# Altium schematic sheet Sheet6.SchDoc(Sheet6)
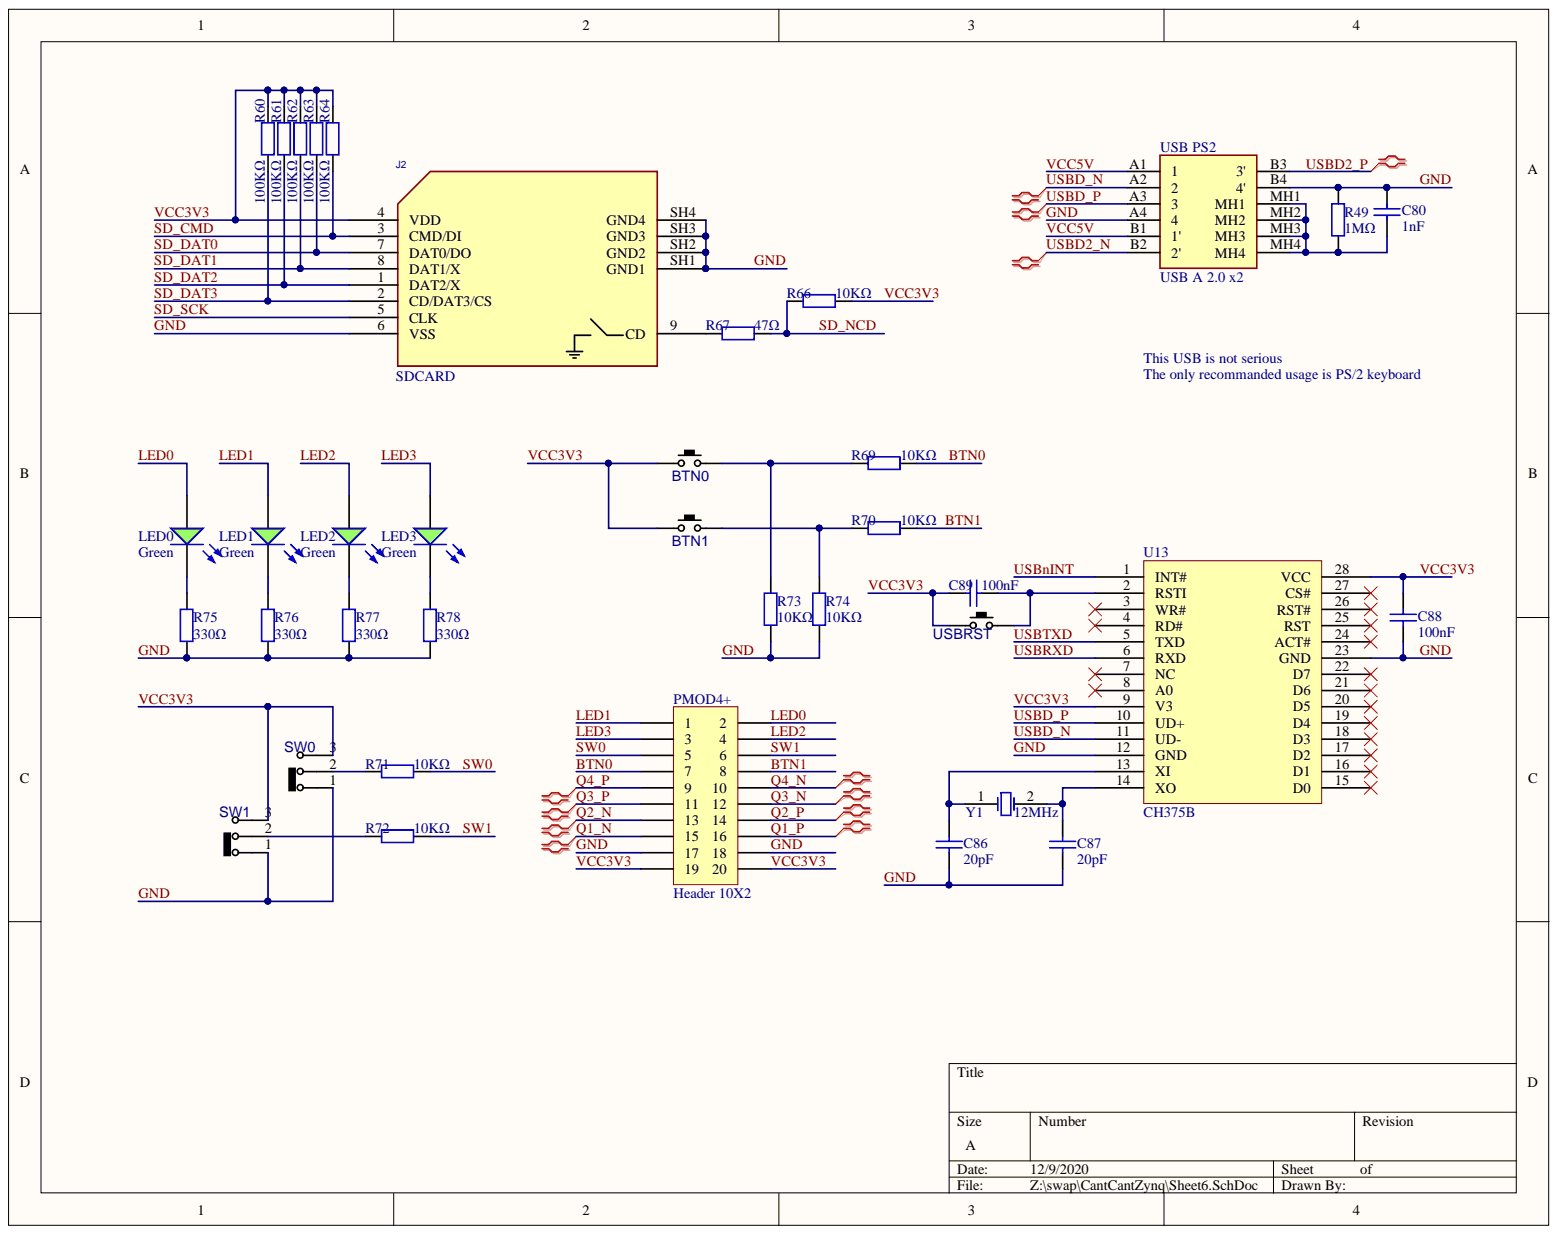
[diagram p0: Sheet6.SchDoc]

## Parts
BTN0  PUSHBUTTON  [SWPBS_SPST_6X3X5]  (value not drawn)
BTN1  PUSHBUTTON  [SWPBS_SPST_6X3X5]  (value not drawn)
C80  1nF  [0805_C]
C86  20pF  [0805_C]
C87  20pF  [0805_C]
C88  100nF  [1206_C]
C89  100nF  [1206_C]
J2  SDCARD  [SDMICRO_AT]
LED0  Green  [0805_LED_S1]
LED1  Green  [0805_LED_S1]
LED2  Green  [0805_LED_S1]
LED3  Green  [0805_LED_S1]
PMOD4+  Header 10X2  [HDR2X10]
R49  1MΩ  [0805_R]
R60  100KΩ  [1206_R]
R61  100KΩ  [1206_R]
R62  100KΩ  [1206_R]
R63  100KΩ  [1206_R]
R64  100KΩ  [1206_R]
R66  10KΩ  [1206_R]
R67  47Ω  [0805_R]
R69  10KΩ  [1206_R]
R70  10KΩ  [1206_R]
R71  10KΩ  [1206_R]
R72  10KΩ  [1206_R]
R73  10KΩ  [1206_R]
R74  10KΩ  [1206_R]
R75  330Ω  [0805_R]
R76  330Ω  [0805_R]
R77  330Ω  [0805_R]
R78  330Ω  [0805_R]
SW0  SlideSwitch  [SWSLIDT_SPDT_S_8X4]  (value not drawn)
SW1  SlideSwitch  [SWSLIDT_SPDT_S_8X4]  (value not drawn)
U13  CH375B  [SOIC-28_300mil]
USB_PS2  USB A 2.0 x2  [0672983090]
USBRST  PUSHBUTTON  [SWPBS_SPST_6X3X5]  (value not drawn)
Y1  12MHz  [SMD-5032_2P]

## Nets
BTN0: PMOD4+.7 R69.2
BTN1: PMOD4+.8 R70.2
GND: C80.1 C86.1 C87.1 C88.1 J2.6(VSS) J2.SH1(GND1) J2.SH2(GND2) J2.SH3(GND3) J2.SH4(GND4) PMOD4+.17 PMOD4+.18 R49.1 R73.1 R74.1 R75.1 R76.1 R77.1 R78.1 SW0.1 SW1.1 U13.12(GND) U13.23(GND) USB_PS2.A4 USB_PS2.B4(4')
LED0: LED0.A PMOD4+.2
LED1: LED1.A PMOD4+.1
LED2: LED2.A PMOD4+.4
LED3: LED3.A PMOD4+.3
Q1_N: PMOD4+.15
Q1_P: PMOD4+.16
Q2_N: PMOD4+.13
Q2_P: PMOD4+.14
Q3_N: PMOD4+.12
Q3_P: PMOD4+.11
Q4_N: PMOD4+.10
Q4_P: PMOD4+.9
SD_CMD: J2.3(CMD/DI) R64.1
SD_DAT0: J2.7(DAT0/DO) R63.1
SD_DAT1: J2.8(DAT1/X) R62.1
SD_DAT2: J2.1(DAT2/X) R61.1
SD_DAT3: J2.2(CD/DAT3/CS) R60.1
SD_NCD: R66.1 R67.2
SD_SCK: J2.5(CLK)
SW0: PMOD4+.5 R71.2
SW1: PMOD4+.6 R72.2
USBD2_N: USB_PS2.B2(2')
USBD2_P: USB_PS2.B3(3')
USBD_N: U13.11(UD-) USB_PS2.A2
USBD_P: U13.10(UD+) USB_PS2.A3
USBnINT: U13.1(INT#)
USBRXD: U13.6(RXD)
USBTXD: U13.5(TXD)
VCC3V3: BTN0.1 BTN1.1 C88.2 C89.2 J2.4(VDD) PMOD4+.19 PMOD4+.20 R60.2 R61.2 R62.2 R63.2 R64.2 R66.2 SW0.3 SW1.3 U13.9(V3) U13.28(VCC) USBRST.1
VCC5V: USB_PS2.A1 USB_PS2.B1(1')
NetBTN0_2: BTN0.2 R69.1 R73.2
NetBTN1_2: BTN1.2 R70.1 R74.2
NetC80_2: C80.2 R49.2 USB_PS2.MH1 USB_PS2.MH2 USB_PS2.MH3 USB_PS2.MH4
NetC86_2: C86.2 U13.13(XI) Y1.1
NetC87_2: C87.2 U13.14(XO) Y1.2
NetC89_1: C89.1 U13.2(RSTI) USBRST.2
NetJ2_9: J2.9(CD) R67.1
NetLED0_K: LED0.K R75.2
NetLED1_K: LED1.K R76.2
NetLED2_K: LED2.K R77.2
NetLED3_K: LED3.K R78.2
NetR71_1: R71.1 SW0.2
NetR72_1: R72.1 SW1.2

Not connected: U13 3(WR#) 4(RD#) 7(NC) 8(A0) 15(D0) 16(D1) 17(D2) 18(D3) 19(D4) 20(D5) 21(D6) 22(D7) 24(ACT#) 25(RST) 26(RST#) 27(CS#)

## Library data of the parts
BTN0 BTN1 USBRST = SWPBS_SPST_6X3X4: SWITCH, PUSH BUTTON, TACTILE, SMD, SPST, 6X3.5X4.3mm; MANF_P#: EVQ-PNF04M; MANF: Panasonic; DESC: DESCRIPTION; VALUE: PUSHBUTTON; PRICE: PRICE; PARAM: PARAM; Component Kind: Standard; ASSEMBLY: ASSEMBLY; Library Name: Switch Push Botton.SchLib
C80 = 0805 1nF (102) 10% 50V: 贴片电容; SuppliersPartNumber: C51210; Supplier: LC; Package: 0805; Library Name: Cap.SchLib; ComponentLink1URL: http://www.szlcsc.com/product/details_52223.html; ComponentLink1Description: 供应商链接; Component Kind: Standard
C86 C87 = 0805 20pF (200) 5% 50V: 贴片电容; SuppliersPartNumber: C1798; Supplier: LC; Package: 0805; Library Name: Cap.SchLib; ComponentLink1URL: http://www.szlcsc.com/product/details_2150.html; ComponentLink1Description: 供应商链接; Component Kind: Standard
C88 C89 = 1206 100nF (104) 10% 50V: 贴片电容; SuppliersPartNumber: C24497; Supplier: LC; Package: 1206; Library Name: Cap.SchLib; ComponentLink1URL: http://www.szlcsc.com/product/details_25237.html; ComponentLink1Description: 供应商链接; Component Kind: Standard
J2 = SOCKET_SDMICRO_AT: SD MICRO exist in Iran, Attend 112J-TDAR-R01; VALUE: SDCARD; MANF_P#: 112J-TDAR-R01; MANF: Attend; Library Name: Connector Micro SD.SchLib; Component Kind: Standard
LED0-LED3 = 0805 翠绿: 发光二极管; SuppliersPartNumber: C2297; Supplier: LC; Package: 0805; Library Name: LED.SchLib; ComponentLink1URL: http://www.szlcsc.com/product/details_2670.html; ComponentLink1Description: 供应商链接; Component Kind: Standard
PMOD4+ = Header 10X2: Header, 10-Pin, Dual row; Signal Integrity: Connector; Library Name: Miscellaneous Connectors.IntLib; LatestRevisionNote: Re-released for DXP Platform.; LatestRevisionDate: 17-Jul-2002; Component Kind: Standard; Publisher: Altium Limited
R49 = 0805 1MΩ (1004) 1%: 贴片电阻; SuppliersPartNumber: C17514; Supplier: LC; Package: 0805; Library Name: RES.SchLib; ComponentLink1URL: http://www.szlcsc.com/product/details_18202.html; ComponentLink1Description: 供应商链接; Component Kind: Standard
R60-R64 = 1206 100KΩ (1003) 1%: 贴片电阻; SuppliersPartNumber: C17900; Supplier: LC; Package: 1206; Library Name: RES.SchLib; ComponentLink1URL: http://www.szlcsc.com/product/details_18588.html; ComponentLink1Description: 供应商链接; Component Kind: Standard
R66 R69-R74 = 1206 10KΩ (1002) 1%: 贴片电阻; SuppliersPartNumber: C17902; Supplier: LC; Package: 1206; Library Name: RES.SchLib; ComponentLink1URL: http://www.szlcsc.com/product/details_18590.html; ComponentLink1Description: 供应商链接; Component Kind: Standard
R67 = 0805 47Ω (47R0) 1%: 贴片电阻; SuppliersPartNumber: C17714; Supplier: LC; Package: 0805; Library Name: RES.SchLib; ComponentLink1URL: http://www.szlcsc.com/product/details_18402.html; ComponentLink1Description: 供应商链接; Component Kind: Standard
R75-R78 = 0805 330Ω (3300) 1%: 贴片电阻; SuppliersPartNumber: C17630; Supplier: LC; Package: 0805; Library Name: RES.SchLib; ComponentLink1URL: http://www.szlcsc.com/product/details_18318.html; ComponentLink1Description: 供应商链接; Component Kind: Standard
SW0 SW1 = SWSLIDT_SPDT_S_8X4: SWITCH, SLIDE, SPDT, 8.6X4.3mm, THD; MANF_PART#: OS102011MS2QN1; MANF: C&K; DESC: DESCRIPTION; VALUE: SlideSwitch; PRICE: 0.4$; PARAM: PARAM; Library Name: Switch Slide.SchLib; Component Kind: Standard; ASSEMBLY: ASSEMBLY
U13 = CH376S_[C14166]: USB; SuppliersPartNumber: C14166; Supplier: LC; Package: SOIC-28_300mil; Library Name: IC.SchLib; ComponentLink1URL: http://www.szlcsc.com/product/details_14823.html; ComponentLink1Description: 供应商链接; Component Kind: Standard
USB_PS2 = 0672983090: Connector; Manufacturer_Name: Molex; Mouser Price/Stock: https://www.mouser.co.uk/ProductDetail/Molex/0672983090?qs=PBcRNPEHKspNkRSIiHq%2Fdg%3D%3D; Mouser Part Number: N/A; Manufacturer_Part_Number: 0672983090; Height: 15.59mm; Datasheet Link: https://componentsearchengine.com/Datasheets/1/0672983090.pdf; Component Kind: Standard; Arrow Price/Stock: https://www.arrow.com/en/products/0672983090/molex; Arrow Part Number: 0672983090
Y1 = SMD-5032_2P 11.0592MHZ 20PF 20PPM: 贴片晶振; SuppliersPartNumber: C52026; Supplier: LC; Package: SMD-5032_2P; Library Name: CrystalOscillator.SchLib; ComponentLink1URL: http://www.szlcsc.com/product/details_53039.html; ComponentLink1Description: 供应商链接; Component Kind: Standard
